# Altium schematic sheet main.SchDoc
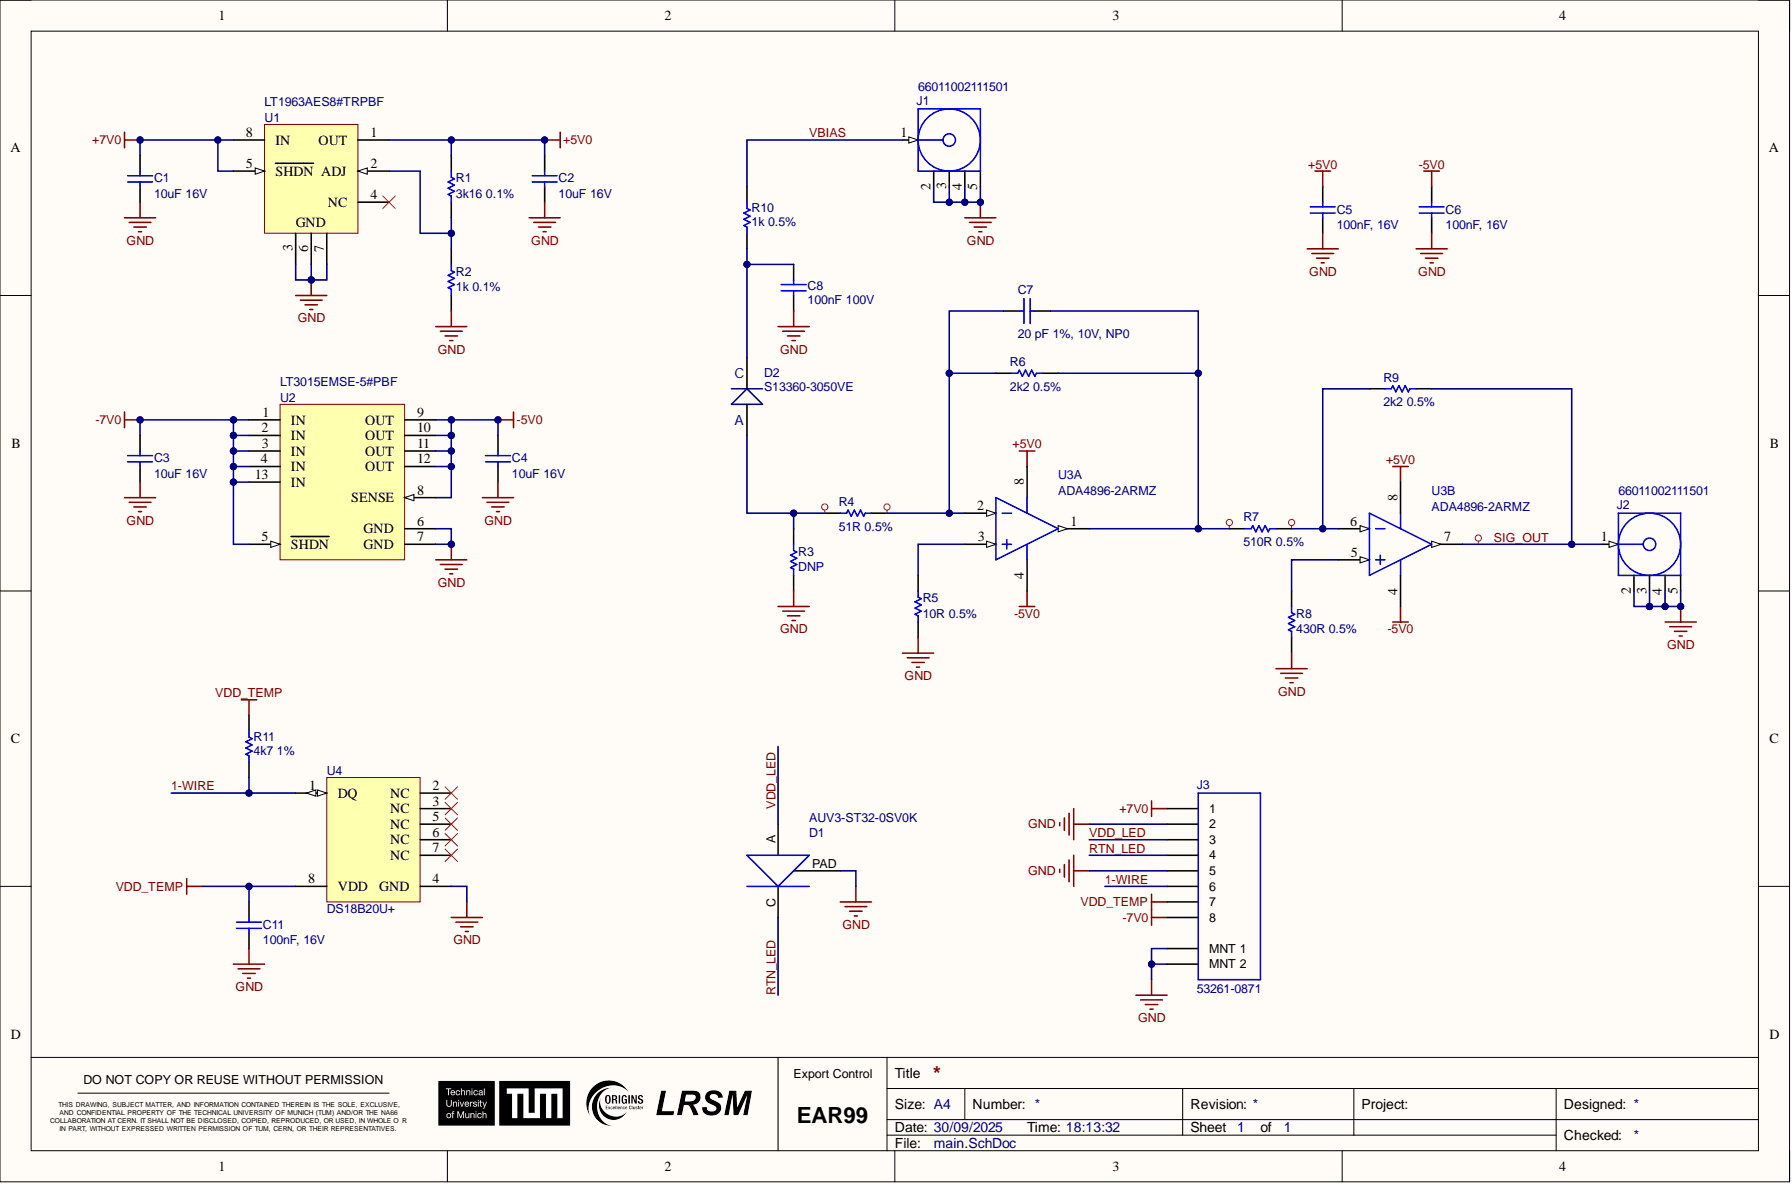
[diagram p0: main.SchDoc]

## Parts
C1  10uF 16V  [WCAP-CSGP_1206_H=1.6mm]
C2  10uF 16V  [WCAP-CSGP_1206_H=1.6mm]
C3  10uF 16V  [WCAP-CSGP_1206_H=1.6mm]
C4  10uF 16V  [WCAP-CSGP_1206_H=1.6mm]
C5  100nF, 16V  [WCAP-CSGP_0402_H=0.5mm]
C6  100nF, 16V  [WCAP-CSGP_0402_H=0.5mm]
C7  20 pF 1%, 10V, NP0  [WCAP-CSGP_0402_H=0.5mm]
C8  100nF 100V  value 100nF  [WCAP-CSGP_0603_H=0.8mm_Reflow]
C11  100nF, 16V  [WCAP-CSGP_0402_H=0.5mm]
D1  AUV3-ST32-0SV0K  [AUV3-ST32-0SV0K-Footprint-1]
D2  S13360-3050VE  [S13360-3050VE-Footprint-1]
J1  66011002111501  [66011002111501]
J2  66011002111501  [66011002111501]
J3  53261-0871  [FP-532610871-MFG]
R1  3k16 0.1%  [RESC0402(1005)_N]
R2  1k 0.1%  Tolerance: 0.1%  [RESC0402(1005)_N]
R3  DNP  [RESC0402(1005)_N]
R4  51R 0.5%  Resistance: 51R  Tolerance: 0.5%  [RESC0402(1005)_N]
R5  10R 0.5%  Resistance: 10R  [RESC0402(1005)_N]
R6  2k2 0.5%  [RESC0402(1005)_N]
R7  510R 0.5%  [RESC0402(1005)_N]
R8  430R 0.5%  Resistance: 430R  [RESC0402(1005)_N]
R9  2k2 0.5%  [RESC0402(1005)_N]
R10  1k 0.5%  Tolerance: 0.5%  [RESC0603(1608)_N]
R11  4k7 1%  Tolerance: 1%  [RESC0402(1005)_N]
U1  LT1963AES8#TRPBF  [SOIC127P600X175-8N]
U2  LT3015EMSE-5#PBF  [TSOP65P490X110_HS-13N]
U3  ADA4896-2ARMZ  [TSOP65P490X110-8N]  (parts A,B)
U4  DS18B20U+  [MAXM-U8+1_V]

## Nets
+5V0: C2.2 C5.2 R1.2 U1.1(OUT) U3.8
+7V0: C1.2 J3.1 U1.5(SHDN) U1.8(IN)
-5V0: C4.2 C6.2 U2.8(SENSE) U2.9(OUT) U2.10(OUT) U2.11(OUT) U2.12(OUT) U3.4
-7V0: C3.2 J3.8 U2.1(IN) U2.2(IN) U2.3(IN) U2.4(IN) U2.5(SHDN) U2.13(IN)
GND: C1.1 C2.1 C3.1 C4.1 C5.1 C6.1 C8.1 C11.1 D1.PAD J1.2 J1.3 J1.4 J1.5 J2.2 J2.3 J2.4 J2.5 J3.2 J3.5 J3.9(MNT_1) J3.10(MNT_2) R2.1 R3.1 R5.1 R8.1 U1.3 U1.6 U1.7 U2.6(GND) U2.7(GND) U4.4(GND)
VDD_TEMP: C11.2 J3.7 R11.2 U4.8(VDD)
1-WIRE: J3.6 R11.1 U4.1(DQ)
RTN_LED: D1.C J3.4
SIG_OUT: J2.1 R9.2 U3.7
VBIAS: J1.1 R10.2
VDD_LED: D1.A J3.3
NetC7_1: C7.1 R4.1 R6.1 U3.2
NetC7_2: C7.2 R6.2 R7.1 U3.1
NetC8_2: C8.2 D2.2 R10.1
NetD2_1: D2.1 R3.2 R4.2
NetR1_1: R1.1 R2.2 U1.2(ADJ)
NetR5_2: R5.2 U3.3
NetR7_2: R7.2 R9.1 U3.6
NetR8_2: R8.2 U3.5

Not connected: U1 4(NC); U4 2(NC) 3(NC) 5(NC) 6(NC) 7(NC)

## Library data of the parts
C1-C4 = CMP-010-0785-1: WCAP-CSGP Multilayer Ceramic Chip Capacitor, General Purpose, size 1206, X7R, 10uF, 16V; Manufacturer: Wurth Elektronik; Manufacturer Part Number: 885012208041; Capacitance Tolerance: +/-10%; Dissipation Factor: #NAME?; Isolation Resistance: >=0.01GOhm; Rated Voltage: 16VDC; Technical Reference: X7R1206106K016DFCT10000; Value: 10µF; Ceramic Type: X7R; Component Kind: Standard; ComponentLink1Description: Manufacturer; ComponentLink1URL: http://www.we-online.de; ComponentLink2Description: Datasheet; ComponentLink2URL: https://www.we-online.com/catalog/datasheet/885012208041.pdf; Design Item ID: 885012208041; Height: 1.6mm; Library Name: Altium Concord Pro on Altium 365; Mounting Technology: SMT; Operating Temperature: -55 to +125degC; Packaging: Tape and Reel; Pins: 2; Published Date: May 2020; Size: 1206; Source: WCAP-CSGP_1206.SchLib
C5 C6 C11 = CMP-010-0480-1: WCAP-CSGP Multilayer Ceramic Chip Capacitor, General Purpose, size 0402, X7R, 100nF, 16V; Manufacturer: Wurth Elektronik; Manufacturer Part Number: 885012205037; Capacitance Tolerance: +/-10%; Dissipation Factor: #NAME?; Isolation Resistance: >=5GOhm; Rated Voltage: 16VDC; Technical Reference: X7R0402104K016DFCT10000; Ceramic Type: X7R; Component Kind: Standard; ComponentLink1Description: Manufacturer; ComponentLink1URL: http://www.we-online.de; ComponentLink2Description: Datasheet; ComponentLink2URL: https://www.we-online.com/catalog/datasheet/885012205037.pdf; Design Item ID: 885012205037; Height: 0.5mm; Mounting Technology: SMT; Operating Temperature: -55 to +125degC; Packaging: Tape and Reel; Pins: 2; Published Date: May 2020; Size: 0402; Source: WCAP-CSGP_0402.SchLib; Value: 100nF; Library Name: LRSM Altium Workspace
C7 = CMP-010-0006-1: WCAP-CSGP Multilayer Ceramic Chip Capacitor, General Purpose, size 0402, NP0, 10pF, 10V; Manufacturer: Wurth Elektronik; Manufacturer Part Number: 885012005007; Capacitance Tolerance: +/-5%; Dissipation Factor: Q>=400+20C; Isolation Resistance: >=10GOhm; Rated Voltage: 10VDC; Technical Reference: NP00402100J010DFCT10000; Ceramic Type: NP0; Component Kind: Standard; ComponentLink1Description: Manufacturer; ComponentLink1URL: http://www.we-online.de; ComponentLink2Description: Datasheet; ComponentLink2URL: https://www.we-online.com/catalog/datasheet/885012005007.pdf; Design Item ID: 885012005007; Height: 0.5mm; Mounting Technology: SMT; Operating Temperature: -55 to +125degC; Packaging: Tape and Reel; Pins: 2; Published Date: May 2020; Size: 0402; Source: WCAP-CSGP_0402.SchLib; Value: 10pF; Library Name: LRSM Altium Workspace
C8 = CMP-010-0623-1: WCAP-CSGP Multilayer Ceramic Chip Capacitor, General Purpose, size 0603, X7R, 100nF, 100V; Manufacturer: Wurth Elektronik; Manufacturer Part Number: 885012206120; Capacitance Tolerance: +/-10%; Dissipation Factor: #NAME?; Isolation Resistance: >=1GOhm; Rated Voltage: 100VDC; Technical Reference: X7R0603104K100DFCT10000; Ceramic Type: X7R; Component Kind: Standard; ComponentLink1Description: Manufacturer; ComponentLink1URL: http://www.we-online.de; ComponentLink2Description: Datasheet; ComponentLink2URL: https://www.we-online.com/catalog/datasheet/885012206120.pdf; Design Item ID: 885012206120; Height: 0.8mm; Mounting Technology: SMT; Operating Temperature: -55 to +125degC; Packaging: Tape and Reel; Pins: 2; Published Date: May 2020; Size: 0603; Source: WCAP-CSGP_0603.SchLib; Library Name: LRSM Altium Workspace
D1 = CMP-00285-1: Component Kind: Standard; Library Name: LRSM Altium Workspace
D2 = CMP-00090-1: MPPC for precision measurement; Component Kind: Standard; Library Name: LRSM Altium Workspace
J1 J2 = CMP-002-00434-1: WR-MMCX PCB Jack, THT, Right Angle, 6GHz, 50O; Manufacturer: Wurth Elektronik; Center Contact Diameter: 0.7mm; Connector Type: MMCX; Interface Type: IEC 61169-52; Category: Coaxial Connectors; Component Kind: Standard; Component Type: Standard; ComponentLink1Description: Website Link; ComponentLink1URL: https://www.we-online.com/redexpert/article/66011002111501?ad; ComponentLink2Description: Datasheet Link; ComponentLink2URL: https://www.we-online.com/redexpert/spec/66011002111501?ad; Design Item ID: 66011002111501; Frequency Range: 6GHz; Gender: Jack; Height: 3.8mm; Impedance: 50O; Length: 6.5mm; Manufacturer Part Number: 66011002111501; Match Code: WR-MMCX; Mount: Through Hole; Operating Temperature Max: 155°C; Operating Temperature Min: -55°C; Orientation: Right Angle; Packaging: Tray; Pin Count: 5; Source: WR-MMCX.SchLib; Width: 3.5mm; Library Name: LRSM Altium Workspace
J3 = CMP-002-00452-2: 1.25mm (.049") Pitch PicoBlade Header, Surface Mount, Right Angle, Lead-free, 8 Circuits; Gender: Male; Component Kind: Standard; Max Operating Temperature: 105°C; Min Operating Temperature: -40°C; Mounting Technology: Surface Mount; Pins: 8; Library Name: LRSM Altium Workspace
R1 = CMP-015-0047-1: Res Thin Film 0402 3.16K Ohm 0.1% 1/16W ±25ppm/°C Molded SMD Punched Carrier T/R; Max Power Dissipation: 63mW; Resistance: 3.16kR; Voltage Rating: 25V; Voltage Rating (DC): 50V; Case Code (Imperial): 0402; Case Code (Metric): 1005; Case/Package: 0402; Component Kind: Standard; Contact Plating: Tin; Depth: 0.5mm; Features: Automotive AEC-Q200; Height: 0.016inch; Lead Free: Lead Free; Length: 0.039inch; Max Operating Temperature: 155°C; Military Standard: Not; Min Operating Temperature: -55°C; Mount: Surface Mount; Number of Terminations: 2; Package Quantity: 1; Packaging: Tape and Reel; Power Rating: 63mW; REACH SVHC: Unknown; Resistor Type: Thin Film; Temperature Coefficient: 25ppm/°C; Termination: SMD/SMT; Tolerance: 0.1%; Weight: 2.8E-05oz; Width: 0.5mm; Library Name: LRSM Altium Workspace
R2 = CMP-009-00196-1: Max Power Dissipation: 63mW; Resistance: 1kR; Temperature Coefficient: 25ppm/°C; Voltage Rating: 25V; Voltage Rating (DC): 50V; Case Code (Imperial): 0402; Case Code (Metric): 1005; Case/Package: 0402; Component Kind: Standard; Composition: Thin Film,Metal Film; Contact Plating: Tin; Depth: 0.5mm; Features: Automotive AEC-Q200; Height: 0.35mm; Lead Free: Lead Free; Length: 1mm; Max Operating Temperature: 155°C; Military Standard: Not; Min Operating Temperature: -55°C; Mount: Surface Mount; Number of Pins: 2; Number of Terminations: 2; Package Quantity: 1; Packaging: Tape and Reel; Power Rating: 63mW; Radiation Hardening: No; REACH SVHC: Unknown; Termination: SMD/SMT; Width: 0.02inch; Library Name: LRSM Altium Workspace
R3 = CMP-009-00205-1: Max Power Dissipation: 63mW; Power Rating: 62.5mW; Resistance: 51R; Temperature Coefficient: 25ppm/°C; Tolerance: 0.5%; Case Code (Imperial): 0402; Case Code (Metric): 1005; Case/Package: 0402; Component Kind: Standard; Composition: Thin Film,Metal Film; Contact Plating: Tin; Depth: 0.5mm; Features: Automotive AEC-Q200; Height: 0.016inch; Lead Free: Lead Free; Length: 1mm; Max Operating Temperature: 155°C; Military Standard: Not; Min Operating Temperature: -55°C; Mount: Surface Mount; Number of Terminations: 2; Package Quantity: 1; Packaging: Tape and Reel; Width: 0.02inch; Library Name: LRSM Altium Workspace
R4 = CMP-009-00205-1: Max Power Dissipation: 63mW; Power Rating: 62.5mW; Temperature Coefficient: 25ppm/°C; Case Code (Imperial): 0402; Case Code (Metric): 1005; Case/Package: 0402; Component Kind: Standard; Composition: Thin Film,Metal Film; Contact Plating: Tin; Depth: 0.5mm; Features: Automotive AEC-Q200; Height: 0.016inch; Lead Free: Lead Free; Length: 1mm; Max Operating Temperature: 155°C; Military Standard: Not; Min Operating Temperature: -55°C; Mount: Surface Mount; Number of Terminations: 2; Package Quantity: 1; Packaging: Tape and Reel; Width: 0.02inch; Library Name: LRSM Altium Workspace
R5 = CMP-015-0063-1: Res, 10R, 0.5%, 0.1W, 0402 Rohs Compliant: Yes; Max Power Dissipation: 100mW; Resistance Tolerance: 5%; Temperature Coefficient: 200ppm/°C; Voltage Rating: 50V; Case Code (Imperial): 0402; Case Code (Metric): 1005; Case/Package: 0402; Component Kind: Standard; Construction: Rectangular; Contact Plating: Tin; Depth: 0.5mm; Height: 0.35mm; Lead Free: Lead Free; Length: 1mm; Max Operating Temperature: 155°C; Military Standard: Not; Min Operating Temperature: -55°C; Mount: Surface Mount; Number of Terminations: 2; Package Quantity: 10000; Packaging: Tape and Reel; Power Rating: 100mW; Radiation Hardening: No; REACH SVHC: No SVHC; Resistor Type: Thick Film; Series: ERJ; Technology: THICK FILM; Termination: SMD/SMT; Tolerance: 5%; Voltage Rating (DC): 50V; Width: 0.5mm; Working Voltage: 50V; Library Name: LRSM Altium Workspace
R6 R9 = CMP-015-0062-1: Res, 2K2, 0.5%, 0.1W, 0402 Rohs Compliant: Yes; Max Power Dissipation: 100mW; Resistance: 2kR; Resistance Tolerance: 1%; Temperature Coefficient: 100ppm/°C; Voltage Rating: 50V; Case Code (Imperial): 0402; Case Code (Metric): 1005; Case/Package: 0402; Component Kind: Standard; Construction: Rectangular; Contact Plating: Tin; Depth: 0.5mm; Features: Automotive AEC-Q200; Height: 0.35mm; Lead Free: Lead Free; Length: 1mm; Max Operating Temperature: 155°C; Military Standard: Not; Min Operating Temperature: -55°C; Mount: Surface Mount; Number of Terminations: 2; Package Quantity: 10000; Packaging: Tape and Reel; Power Rating: 100mW; Radiation Hardening: No; REACH SVHC: No SVHC; Resistor Type: Thick Film; Series: ERJ; Technology: THICK FILM; Termination: SMD/SMT; Tolerance: 1%; Voltage Rating (DC): 50V; Width: 0.02inch; Working Voltage: 50V; Library Name: LRSM Altium Workspace
R7 = CMP-015-0064-1: Max Power Dissipation: 100mW; Resistance: 523R; Resistance Tolerance: 1%; Temperature Coefficient: 100ppm/°C; Voltage Rating: 50V; Case Code (Imperial): 0402; Case Code (Metric): 1005; Case/Package: 0402; Component Kind: Standard; Construction: Rectangular; Contact Plating: Tin; Depth: 0.5mm; Features: Automotive AEC-Q200; Height: 0.016inch; Lead Free: Contains Lead; Length: 1mm; Max Operating Temperature: 155°C; Military Standard: Not; Min Operating Temperature: -55°C; Mount: Surface Mount; Number of Terminations: 2; Package Quantity: 1; Packaging: Tape and Reel; Power Rating: 100mW; REACH SVHC: Yes; Resistor Type: Thick Film; Series: ERJ; Termination: SMD/SMT; Tolerance: 1%; Voltage Rating (DC): 50V; Weight: 2.8E-05oz; Width: 0.5mm; Library Name: LRSM Altium Workspace
R8 = CMP-015-0065-1: Res Thick Film 0402 430 Ohm 0.5% 0.063W(1/16W) ±50ppm/C Pad SMD Automotive T/R; Max Power Dissipation: 100mW; Power Rating: 63mW; Temperature Coefficient: 50ppm/°C; Voltage Rating: 50V; Case Code (Imperial): 0402; Case Code (Metric): 1005; Case/Package: 0402; Component Kind: Standard; Contact Plating: Tin; Depth: 0.5mm; Features: Automotive AEC-Q200; Height: 0.35mm; Lead Free: Lead Free; Length: 1mm; Max Operating Temperature: 125°C; Military Standard: Not; Min Operating Temperature: -55°C; Mount: Surface Mount; Number of Terminations: 2; Package Quantity: 1; Packaging: Cut Tape; REACH SVHC: No SVHC; Resistor Type: Thick Film; Termination: Solder; Tolerance: 0.5%; Voltage Rating (DC): 50V; Width: 0.02inch; Library Name: LRSM Altium Workspace
R10 = CMP-015-0043-1: Res Thick Film 0603 1K Ohm 0.5% 0.063W ±50ppm/°C Molded SMD Punched Carrier T/R; Power Rating: 100mW; Resistance: 1kR; Voltage Rating: 75V; Case Code (Imperial): 0603; Case Code (Metric): 1608; Case/Package: 0603; Component Kind: Standard; Contact Plating: Tin; Height: 0.45mm; Lead Free: Lead Free; Length: 1.6mm; Max Operating Temperature: 125°C; Min Operating Temperature: -55°C; Mount: Surface Mount; Package Quantity: 5000; Packaging: Tape and Reel; Resistor Type: Thick Film; Series: ERA-3A; Temperature Coefficient: 50ppm/°C; Termination: SMD/SMT; Width: 0.8mm; Library Name: LRSM Altium Workspace
R11 = CMP-009-00213-1: Max Power Dissipation: 100mW; Resistance: 4.7kR; Temperature Coefficient: 100ppm/°C; Voltage Rating: 50V; Case Code (Imperial): 0402; Case Code (Metric): 1005; Case/Package: 0402; Component Kind: Standard; Contact Plating: Tin; Depth: 0.5mm; Features: Automotive AEC-Q200; Height: 0.35mm; Lead Free: Lead Free; Length: 1mm; Max Operating Temperature: 155°C; Military Standard: Not; Min Operating Temperature: -55°C; Mount: Surface Mount; Number of Pins: 2; Number of Terminations: 2; Package Quantity: 1; Packaging: Tape and Reel; Power Rating: 100mW; Radiation Hardening: No; REACH SVHC: No SVHC; Resistor Type: Thick Film; Termination: SMD/SMT; Voltage Rating (DC): 50V; Width: 0.02inch; Library Name: LRSM Altium Workspace
U1 = CMP-00189-1: IC REG LDO ADJ 1.5A 8SOIC; Component Kind: Standard; Library Name: LRSM Altium Workspace
U2 = CMP-00188-1: LT3015 - 1.5A, Low Noise, Negative Linear Regulator with Precision Current Limit; Component Kind: Standard; Library Name: LRSM Altium Workspace
U3 = CMP-00187-1: Op Amp Dual Low Power Amplifier R-R O/P ±5V/10V 8-Pin MSOP Tube; Component Kind: Standard; PartId: 1; Library Name: LRSM Altium Workspace
U4 = CMP-019-00016-2: Programmable Resolution 1-Wire Digital Thermometer, -55 to 125 degC, 8-Pin SOP, RoHS, Tube; Manufacturer: Maxim; Interface: Serial; Max Supply Voltage: 5.5V; Max Supply Voltage (DC): 5V; Min Supply Voltage: 3V; Nominal Supply Current: 1mA; Output Current: 4mA; Case/Package: MSOP; Component Kind: Standard; Datasheet URL: http://datasheets.maximintegrated.com/en/ds/DS18B20.pdf; Datasheet Version: Rev. 4, 01/2015; Manufacturer URL: http://www.maximintegrated.com/; Max Operating Temperature: 125°C; Min Operating Temperature: -55°C; Min Supply Voltage (DC): 3V; Mounting Technology: SM; Package Description: 8-Pin Small Outline Package Integrated Circuit, Body 3 x 3 mm, Pitch 0.65 mm; Package Quantity: 50; Package Reference: U8+1; Package URL: http://pdfserv.maximintegrated.com/package_dwgs/21-0036.PDF; Packaging: Rail/Tube; Pins: 8; RoHS Compliant: True; Library Name: LRSM Altium Workspace
